# Altium schematic sheet heater_control.SchDoc
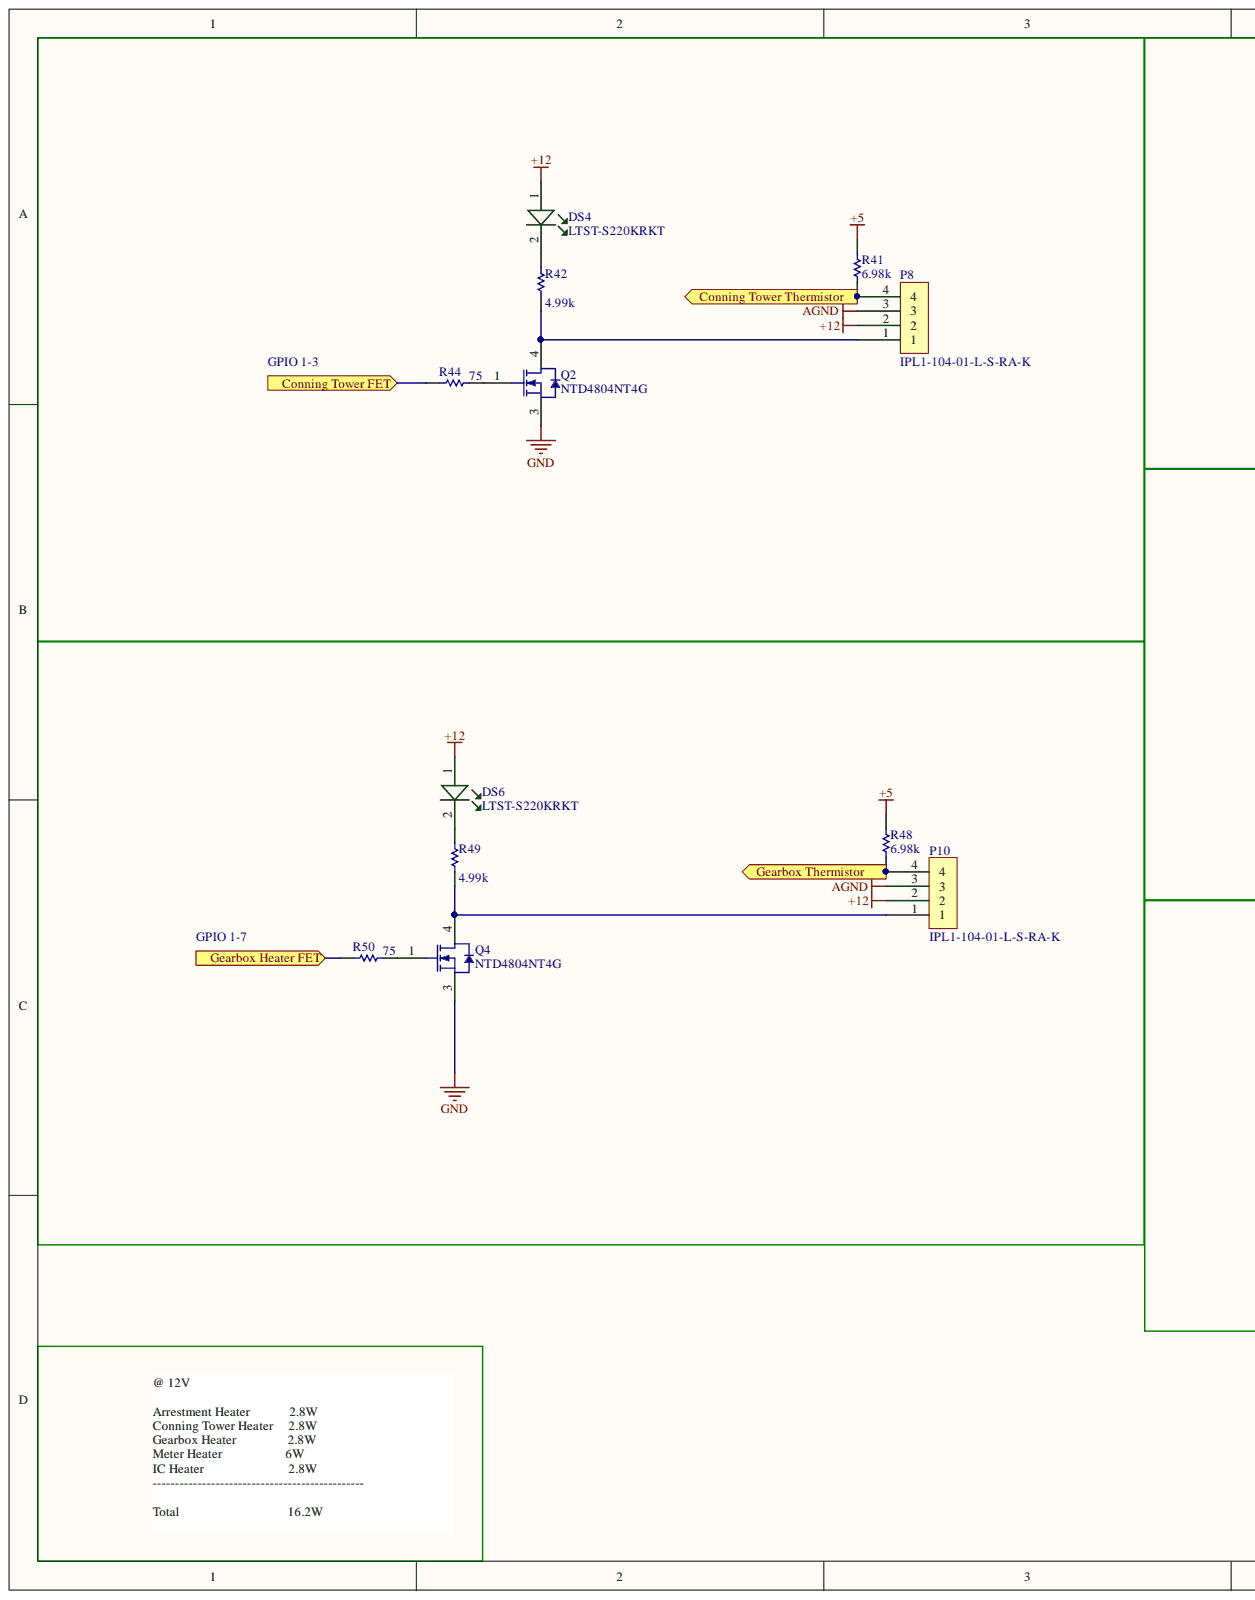
[diagram p0: heater_control.SchDoc, left]
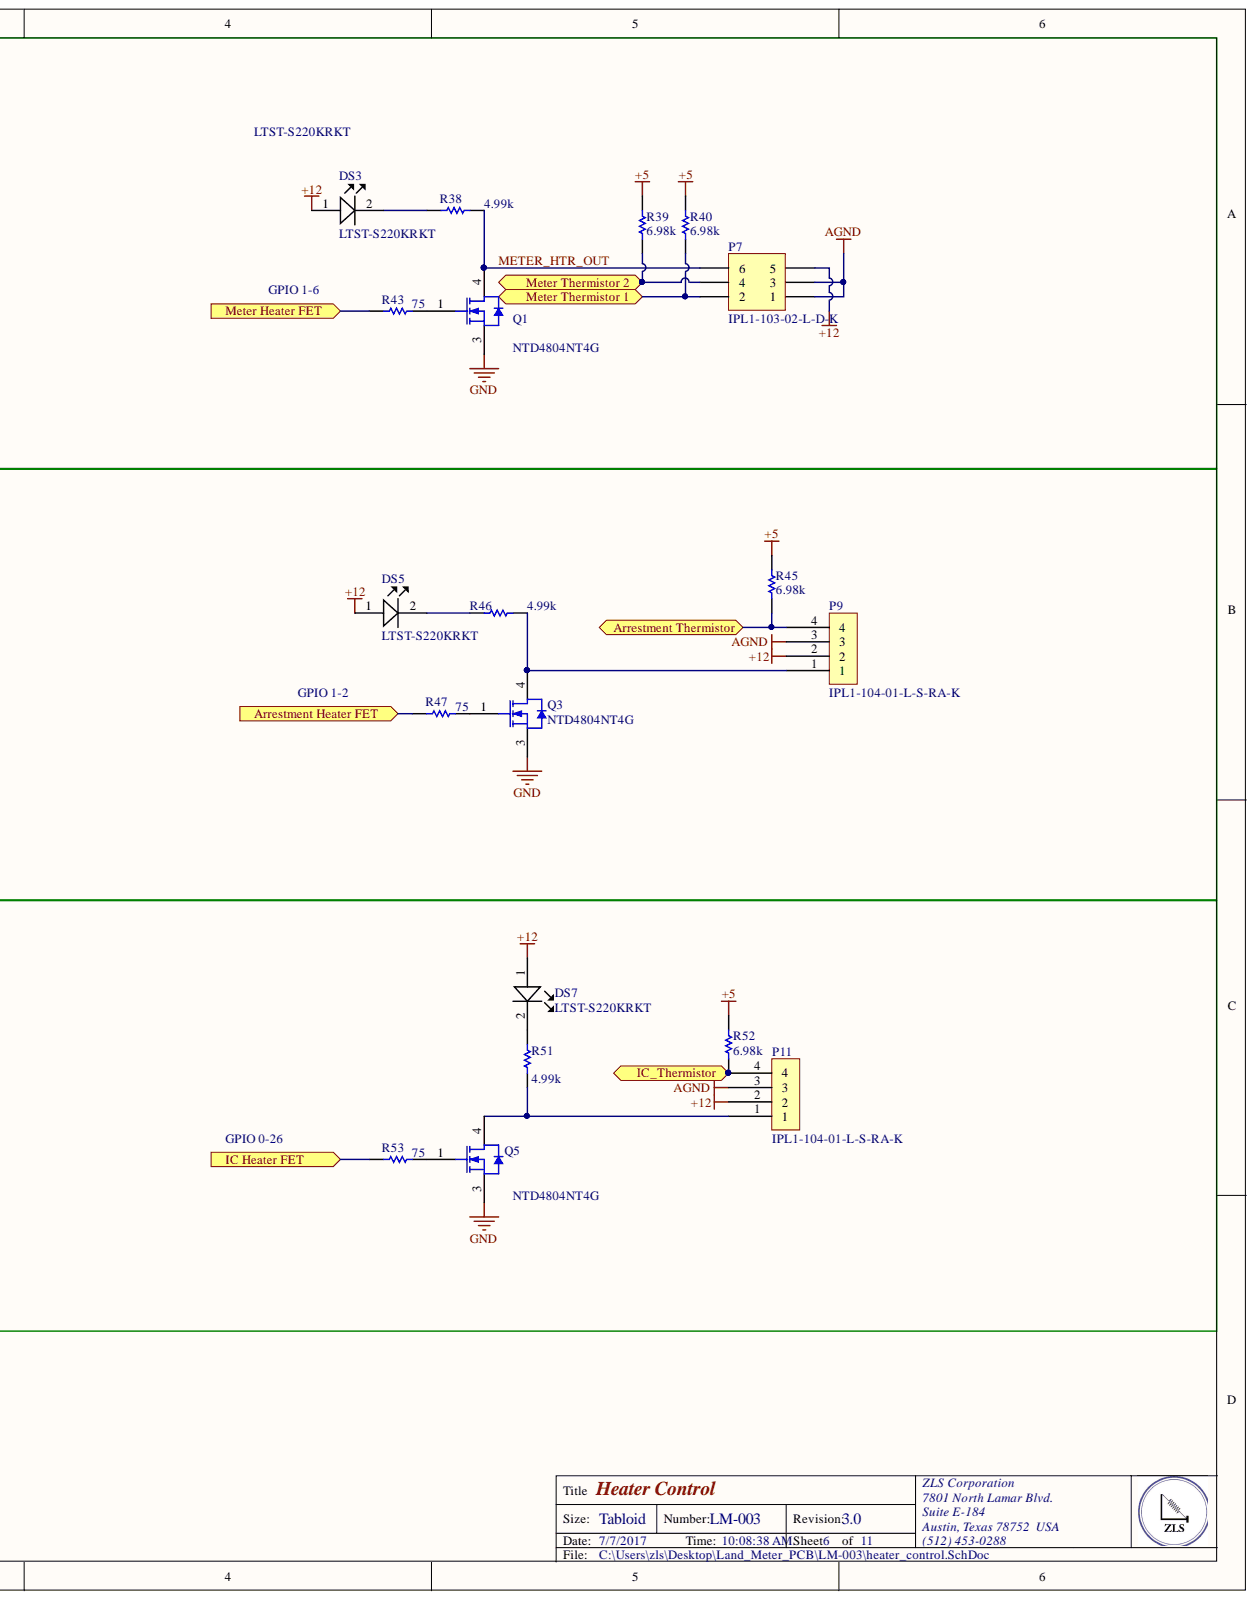
[diagram p1: heater_control.SchDoc, right]

## Parts
DS3  Manufacturer Part Number: LTST-S220KRKT  [0805C_L]  (hidden comment: Visible Name Value Type    False Description Lite-On LTST-S220KRKT Red LED, 631 nm 2012 (0805) Side View, Rectangle Lens SMD package STRING)
DS4  Manufacturer Part Number: LTST-S220KRKT  [0805C_L]  (hidden comment: Visible Name Value Type    False Description Lite-On LTST-S220KRKT Red LED, 631 nm 2012 (0805) Side View, Rectangle Lens SMD package STRING)
DS5  Manufacturer Part Number: LTST-S220KRKT  [0805C_L]  (hidden comment: Visible Name Value Type    False Description Lite-On LTST-S220KRKT Red LED, 631 nm 2012 (0805) Side View, Rectangle Lens SMD package STRING)
DS6  Manufacturer Part Number: LTST-S220KRKT  [0805C_L]  (hidden comment: Visible Name Value Type    False Description Lite-On LTST-S220KRKT Red LED, 631 nm 2012 (0805) Side View, Rectangle Lens SMD package STRING)
DS7  Manufacturer Part Number: LTST-S220KRKT  [0805C_L]  (hidden comment: Visible Name Value Type    False Description Lite-On LTST-S220KRKT Red LED, 631 nm 2012 (0805) Side View, Rectangle Lens SMD package STRING)
P7  IPL1-103-02-L-D-K  [IPL1-103-01-D]
P8  IPL1-104-01-L-S-RA-K  [IPL1-104-01]
P9  IPL1-104-01-L-S-RA-K  [IPL1-104-01]
P10  IPL1-104-01-L-S-RA-K  [IPL1-104-01]
P11  IPL1-104-01-L-S-RA-K  [IPL1-104-01]
Q1  NTD4804NT4G  [ONSC-DPAK-3-369AA-01_V]
Q2  NTD4804NT4G  [ONSC-DPAK-3-369AA-01_V]
Q3  NTD4804NT4G  [ONSC-DPAK-3-369AA-01_V]
Q4  NTD4804NT4G  [ONSC-DPAK-3-369AA-01_V]
Q5  NTD4804NT4G  [ONSC-DPAK-3-369AA-01_V]
R38  Resistance (Ohms): 4.99k  [RESC0805(2012)_L]
R39  Resistance (Ohms): 6.98k  [RESC0805(2012)_N]  (hidden comment: 6K98 1% 0805(2012))
R40  Resistance (Ohms): 6.98k  [RESC0805(2012)_N]  (hidden comment: 6K98 1% 0805(2012))
R41  Resistance (Ohms): 6.98k  [RESC0805(2012)_N]  (hidden comment: 6K98 1% 0805(2012))
R42  Resistance (Ohms): 4.99k  [RESC0805(2012)_L]
R43  (no value)  [RESC0805(2012)_L]
R44  (no value)  [RESC0805(2012)_L]
R45  Resistance (Ohms): 6.98k  [RESC0805(2012)_N]  (hidden comment: 6K98 1% 0805(2012))
R46  Resistance (Ohms): 4.99k  [RESC0805(2012)_L]
R47  (no value)  [RESC0805(2012)_L]
R48  Resistance (Ohms): 6.98k  [RESC0805(2012)_N]  (hidden comment: 6K98 1% 0805(2012))
R49  Resistance (Ohms): 4.99k  [RESC0805(2012)_L]
R50  (no value)  [RESC0805(2012)_L]
R51  Resistance (Ohms): 4.99k  [RESC0805(2012)_L]
R52  Resistance (Ohms): 6.98k  [RESC0805(2012)_N]  (hidden comment: 6K98 1% 0805(2012))
R53  (no value)  [RESC0805(2012)_L]

## Ports
Arrestment Heater FET, Arrestment Thermistor, Conning Tower FET, Conning Tower Thermistor, Gearbox Heater FET, Gearbox Thermistor, IC Heater FET, IC_Thermistor, Meter Heater FET, Meter Thermistor 1, Meter Thermistor 2

## Nets
+5: R39.1 R40.2 R41.2 R45.1 R48.2 R52.1
+12: DS3.1 DS4.1 DS5.1 DS6.1 DS7.1 P7.5 P8.2 P9.2 P10.2 P11.2
AGND: P7.1 P7.3 P8.3 P9.3 P10.3 P11.3
GND: Q1.3 Q2.3 Q3.3 Q4.3 Q5.3
Arrestment Heater FET: R47.1 ; port Arrestment Heater FET
Arrestment Thermistor: P9.4 R45.2 ; port Arrestment Thermistor
Conning Tower FET: R44.1 ; port Conning Tower FET
Conning Tower Thermistor: P8.4 R41.1 ; port Conning Tower Thermistor
Gearbox Heater FET: R50.1 ; port Gearbox Heater FET
Gearbox Thermistor: P10.4 R48.1 ; port Gearbox Thermistor
IC Heater FET: R53.1 ; port IC Heater FET
IC_Thermistor: P11.4 R52.2 ; port IC_Thermistor
Meter Heater FET: R43.1 ; port Meter Heater FET
Meter Thermistor 1: P7.2 R40.1 ; port Meter Thermistor 1
Meter Thermistor 2: P7.4 R39.2 ; port Meter Thermistor 2
METER_HTR_OUT: P7.6 Q1.4 R38.2
NetDS3_2: DS3.2 R38.1
NetDS4_2: DS4.2 R42.2
NetDS5_2: DS5.2 R46.1
NetDS6_2: DS6.2 R49.2
NetDS7_2: DS7.2 R51.1
NetP8_1: P8.1 Q2.4 R42.1
NetP9_1: P9.1 Q3.4 R46.2
NetP10_1: P10.1 Q4.4 R49.1
NetP11_1: P11.1 Q5.4 R51.2
NetQ1_1: Q1.1 R43.2
NetQ2_1: Q2.1 R44.2
NetQ3_1: Q3.1 R47.2
NetQ4_1: Q4.1 R50.2
NetQ5_1: Q5.1 R53.2

## Library data of the parts
DS3-DS7 = LED A/K: Manufacturer: Vishay / Lite-On; Dominant Wavelength: 631nm; Forward Current: 20mA; Forward Voltage: 2V; Lens Colour: Colorless; Lens Style: Diffused,Colorless; Lens Transparency: Clear; Luminous Intensity: 54mcd; Number of Elements: 1; Power Dissipation: 75mW; Viewing Angle: 130°; Wavelength: 639nm; Case/Package: 0805; Case Code (Imperial): 0805; Case Code (Metric): 2012; Colour: Red; Component Kind: Standard; ComponentLink1Description: https://datasheet.ciiva.com/2110/s-110-ltst-s220krkt-2110132.pdf; ComponentLink1URL: https://datasheet.ciiva.com/2110/s-110-ltst-s220krkt-2110132.pdf; ComponentLink2Description: https://datasheet.ciiva.com/2110/s-110-ltst-s220krkt-2110133.pdf; ComponentLink2URL: https://datasheet.ciiva.com/2110/s-110-ltst-s220krkt-2110133.pdf; ComponentLink3Description: https://datasheet.ciiva.com/2110/s-110-ltst-s220krkt-2110134.pdf; ComponentLink3URL: https://datasheet.ciiva.com/2110/s-110-ltst-s220krkt-2110134.pdf; ComponentLink4Description: https://datasheet.ciiva.com/12403/lite-on-ltst-s220krkt-187389-12403798.pdf; ComponentLink4URL: https://datasheet.ciiva.com/12403/lite-on-ltst-s220krkt-187389-12403798.pdf; ComponentLink5Description: https://datasheet.ciiva.com/16345/lite-onltst-s220krkt-16345511.pdf; ComponentLink5URL: https://datasheet.ciiva.com/16345/lite-onltst-s220krkt-16345511.pdf; ComponentLink6Description: https://datasheet.ciiva.com/15118/getdatasheetpartid-225752-15118120.pdf; ComponentLink6URL: https://datasheet.ciiva.com/15118/getdatasheetpartid-225752-15118120.pdf; ComponentLink7Description: https://datasheet.ciiva.com/14633/0900766b80dc0b76-14633737.pdf; ComponentLink7URL: https://datasheet.ciiva.com/14633/0900766b80dc0b76-14633737.pdf; ComponentLink8Description: https://datasheet.ciiva.com/11775/0900766b80dc0b76-11775439.pdf; ComponentLink8URL: https://datasheet.ciiva.com/11775/0900766b80dc0b76-11775439.pdf; Depth: 0.6mm; Description: Visible Name Value Type    False Description Lite-On LTST-S220KRKT Red LED, 631 nm 2012 (0805) Side View, Rectangle Lens SMD package STRING; Height: 0.6mm; Illumination Colour: Red; Lead Free: Lead Free; Length: 2.1mm; Max Operating Temperature: 85°C; Min Operating Temperature: -55°C; Mount: Surface Mount; Number of LEDs: 1; Number of Pins: 2; Package Quantity: 4000; Packaging: Tape and Reel; Power Rating: 75mW; Pricing 1: 1=0.31, 10=0.225, 25=0.1656, 100=0.1183, 250=0.0804, 500=0.071, 1000=0.0556 (USD); Radiation Hardening: No; Stock 1: 108191; Supplier 1: Digi-Key; Supplier Part Number 1: 160-1469-1-ND; Test Current: 20mA; Width: 1mm
P7 = Header 3X2H: CONN SHROUDED PWR HEADER 3POS; Manufacturer 1: Samtec Inc.; Category 1: Connectors, Interconnects; Contact Length - Mating: 0.215" (5.46mm); Material Flammability Rating: UL94 V-0; Number of Positions: 6; Number of Positions Loaded: All; Pitch - Mating: 0.100" (2.54mm); Shrouding: Shrouded - 4 Wall; Style: Board to Cable/Wire; Voltage Rating: 675VAC, 954VDC; Applications: -; Component Kind: Standard; ComponentLink1Description: http://suddendocs.samtec.com/prints/ipl1-1xx-xx-xxx-d-xx-x-xx-mkt.pdf; ComponentLink1URL: http://suddendocs.samtec.com/prints/ipl1-1xx-xx-xxx-d-xx-x-xx-mkt.pdf; Connector Type: Header; Contact Finish - Mating: Gold; Contact Finish - Post: Tin; Contact Finish Thickness - Mating: 10µin (0.25µm); Contact Finish Thickness - Post: -; Contact Length - Post: -; Contact Material: Phosphor Bronze; Contact Shape: Square; Contact Type: Male Pin; Current Rating: -; Description 1: CONN SHROUDED PWR HEADER 3POS; Fastening Type: Locking Ramp; Features: -; Ingress Protection: -; Insulation Color: Natural; Insulation Height: 0.320" (8.13mm); Insulation Material: Liquid Crystal Polymer (LCP); Library Name: Miscellaneous Connectors.IntLib; Manufacturer Part Number 1: IPL1-103-02-L-D-K; Mated Stacking Heights: -; Mounting Type: Surface Mount; Number of Rows: 2; Operating Temperature: -55°C ~ 125°C; Overall Contact Length: -; Packaging: Tube; Part Status: Active; Pricing 1: 1=3.13, 10=3, 25=2.75, 50=2.625, 100=2.5, 250=2.18752, 500=2.125, 1000=1.8125, 2500=1.6875 (USD); RoHS 1: RoHS Compliant; Row Spacing - Mating: 0.100" (2.54mm); Signal Integrity: Connector; Stock 1: 180; Supplier 1: Digi-Key; Supplier Part Number 1: SAM10574-ND; Termination: Solder
P8-P11 = 53047-0410: .100 TERMINAL ASSY; Manufacturer 1: Samtec Inc.; Category 1: Connectors, Interconnects; Connector Type: Header; Contact Length - Mating: 0.215" (5.46mm); Contact Length - Post: 0.085" (2.16mm); Material Flammability Rating: UL94 V-0; Number of Positions: 4; Number of Positions Loaded: All; Pitch - Mating: 0.100" (2.54mm); Shrouding: Shrouded - 4 Wall; Style: Board to Cable/Wire; Voltage Rating: 675VAC, 954VDC; Applications: -; Component Kind: Standard; ComponentLink1Description: http://suddendocs.samtec.com/prints/ipl1-1xx-xx-xxx-s-xx-x-xx-mkt.pdf; ComponentLink1URL: http://suddendocs.samtec.com/prints/ipl1-1xx-xx-xxx-s-xx-x-xx-mkt.pdf; Contact Finish - Mating: Gold; Contact Finish - Post: Tin; Contact Finish Thickness - Mating: 10µin (0.25µm); Contact Finish Thickness - Post: -; Contact Material: Phosphor Bronze; Contact Shape: Square; Contact Type: Male Pin; Current Rating: -; Description 1: .100 TERMINAL ASSY; Fastening Type: Locking Ramp; Features: -; Ingress Protection: -; Insulation Color: Natural; Insulation Height: 0.240" (6.10mm); Insulation Material: Liquid Crystal Polymer (LCP); Manufacturer Part Number 1: IPL1-104-01-L-S-RA-K; Mated Stacking Heights: -; Mounting Type: Through Hole, Right Angle; Number of Rows: 1; Operating Temperature: -55°C ~ 125°C; Overall Contact Length: -; Packaging: Tube; Part Status: Active; Pricing 1: 1=2.53, 10=2.424, 25=2.222, 50=2.121, 100=2.02, 250=1.76752, 500=1.717, 1000=1.4645, 2500=1.3635 (USD); RoHS 1: RoHS Compliant; Row Spacing - Mating: -; Stock 1: 100; Supplier 1: Digi-Key; Supplier Part Number 1: SAM9597-ND; Termination: Solder; Library Name: ZLS_LIB.SchLib
Q1-Q5 = CMP-1058-00341-1: Power MOSFET, 30 V, 117 A, Single N-Channel, 3-Pin DPAK, Pb-Free, Tape and Reel; Manufacturer: On Semiconductor; Channel Polarity: N Channel; Ciss Typ (pF): 4490; Coss Typ (pF): 952; Crss Typ (pF): 556; ID Max (A): 117; Qrr Typ (nC): 30; VGS(th) Max (V): 2.5; VGS Max (V): 20; Component Kind: Standard; ComponentLink1Description: Manufacturer URL; ComponentLink1URL: http://www.onsemi.com/; ComponentLink2Description: Datasheet; ComponentLink2URL: http://www.onsemi.cn/pub_link/Collateral/NTD4804N-D.PDF; ComponentLink3Description: Package Specification; ComponentLink3URL: http://www.onsemi.cn/pub_link/Collateral/369AA.PDF; Configuration: Single; DatasheetVersion: Rev. 8; Library Name: Altium Content Vault; Mounting Technology: Surface Mount; PackageDescription: 3-Pin Flange Mount, Body 6.54 x 6.095 mm, Pitch 2.29 mm; PackageReference: DPAK-3-369AA-01; Package Type: DPAK-3; PackageVersion: Rev. B, 06/2010; Packing: Tape and Reel; PartNumber: NTD4804NT4G; RoHS: Pb-Free; Supplier 1: Digi-Key; Supplier Part Number 1: NTD4804NT4GOSDKR-ND; V(BR)DSS Min (V): 30
R38 R42 R46 R49 R51 = CMP-1013-00393-1: Manufacturer: Vishay Dale; Manufacturer Part Number: CRCW08054K99FKEA; Power (Watts): 0.125W, 1/8W; Temperature Coefficient: ±100ppm/°C; Tolerance: ±1%; Category: Resistors; Component Kind: Standard; ComponentLink1Description: http://www.vishay.com/docs/20035/dcrcwe3.pdf; ComponentLink1URL: http://www.vishay.com/docs/20035/dcrcwe3.pdf; Composition: Thick Film; Failure Rate: -; Features: Automotive AEC-Q200; Height - Seated (Max): 0.020" (0.50mm); Library Name: Altium Content Vault; Operating Temperature: -55°C ~ 155°C; Package / Case: 0805 (2012 Metric); Packaging: Cut Tape (CT); Part Status: Active; Pricing 1: 1=0.1, 10=0.044, 100=0.0178, 1000=0.00802, 2500=0.00696 (USD); RoHS: RoHS Compliant; Size / Dimension: 0.079" L x 0.049" W (2.00mm x 1.25mm); Stock 1: 93740; Supplier 1: Digi-Key; Supplier Device Package: 0805; Supplier Part Number 1: 541-4.99KCCT-ND
R39-R41 R45 R48 R52 = CMP-1013-00606-1: 6K98 0.125W 1% 0805 (2012 Metric)  SMD; Manufacturer: Vishay Dale; Manufacturer Part Number: CRCW08056K98FKEA; Power (Watts): 0.125W, 1/8W; Temperature Coefficient: ±100ppm/°C; Category: Resistors; Component Kind: Standard; ComponentLink1Description: http://www.vishay.com/docs/20035/dcrcwe3.pdf; ComponentLink1URL: http://www.vishay.com/docs/20035/dcrcwe3.pdf; Composition: Thick Film; Failure Rate: -; Features: Automotive AEC-Q200; Height - Seated (Max): 0.020" (0.50mm); Library Name: Altium Content Vault; Operating Temperature: -55°C ~ 155°C; Package / Case: 0805 (2012 Metric); Packaging: Cut Tape (CT); Part Status: Active; Pricing 1: 1=0.1, 10=0.044, 100=0.0178, 1000=0.00802, 2500=0.00696 (USD); RoHS: RoHS Compliant; Size / Dimension: 0.079" L x 0.049" W (2.00mm x 1.25mm); Stock 1: 34245; Supplier 1: Digi-Key; Supplier Device Package: 0805; Supplier Part Number 1: 541-6.98KCCT-ND; Tolerance: ±1%
R43 R44 R47 R50 R53 = CMP-1013-00393-1: Manufacturer: Vishay Dale; Manufacturer Part Number: CRCW080575R0FKEA; Power (Watts): 0.125W, 1/8W; Resistance (Ohms): 75; Temperature Coefficient: ±100ppm/°C; Tolerance: ±1%; Category: Resistors; Component Kind: Standard; ComponentLink1Description: http://www.vishay.com/docs/20035/dcrcwe3.pdf; ComponentLink1URL: http://www.vishay.com/docs/20035/dcrcwe3.pdf; Composition: Thick Film; Failure Rate: -; Features: Automotive AEC-Q200; Height - Seated (Max): 0.020" (0.50mm); Library Name: Altium Content Vault; Operating Temperature: -55°C ~ 155°C; Package / Case: 0805 (2012 Metric); Packaging: Cut Tape (CT); Part Status: Active; Pricing 1: 1=0.1, 10=0.044, 100=0.0178, 1000=0.00802, 2500=0.00696 (USD); RoHS: RoHS Compliant; Size / Dimension: 0.079" L x 0.049" W (2.00mm x 1.25mm); Stock 1: 32546; Supplier 1: Digi-Key; Supplier Device Package: 0805; Supplier Part Number 1: 541-75.0CCT-ND
